# Altium schematic sheet RF_AMP.SchDoc
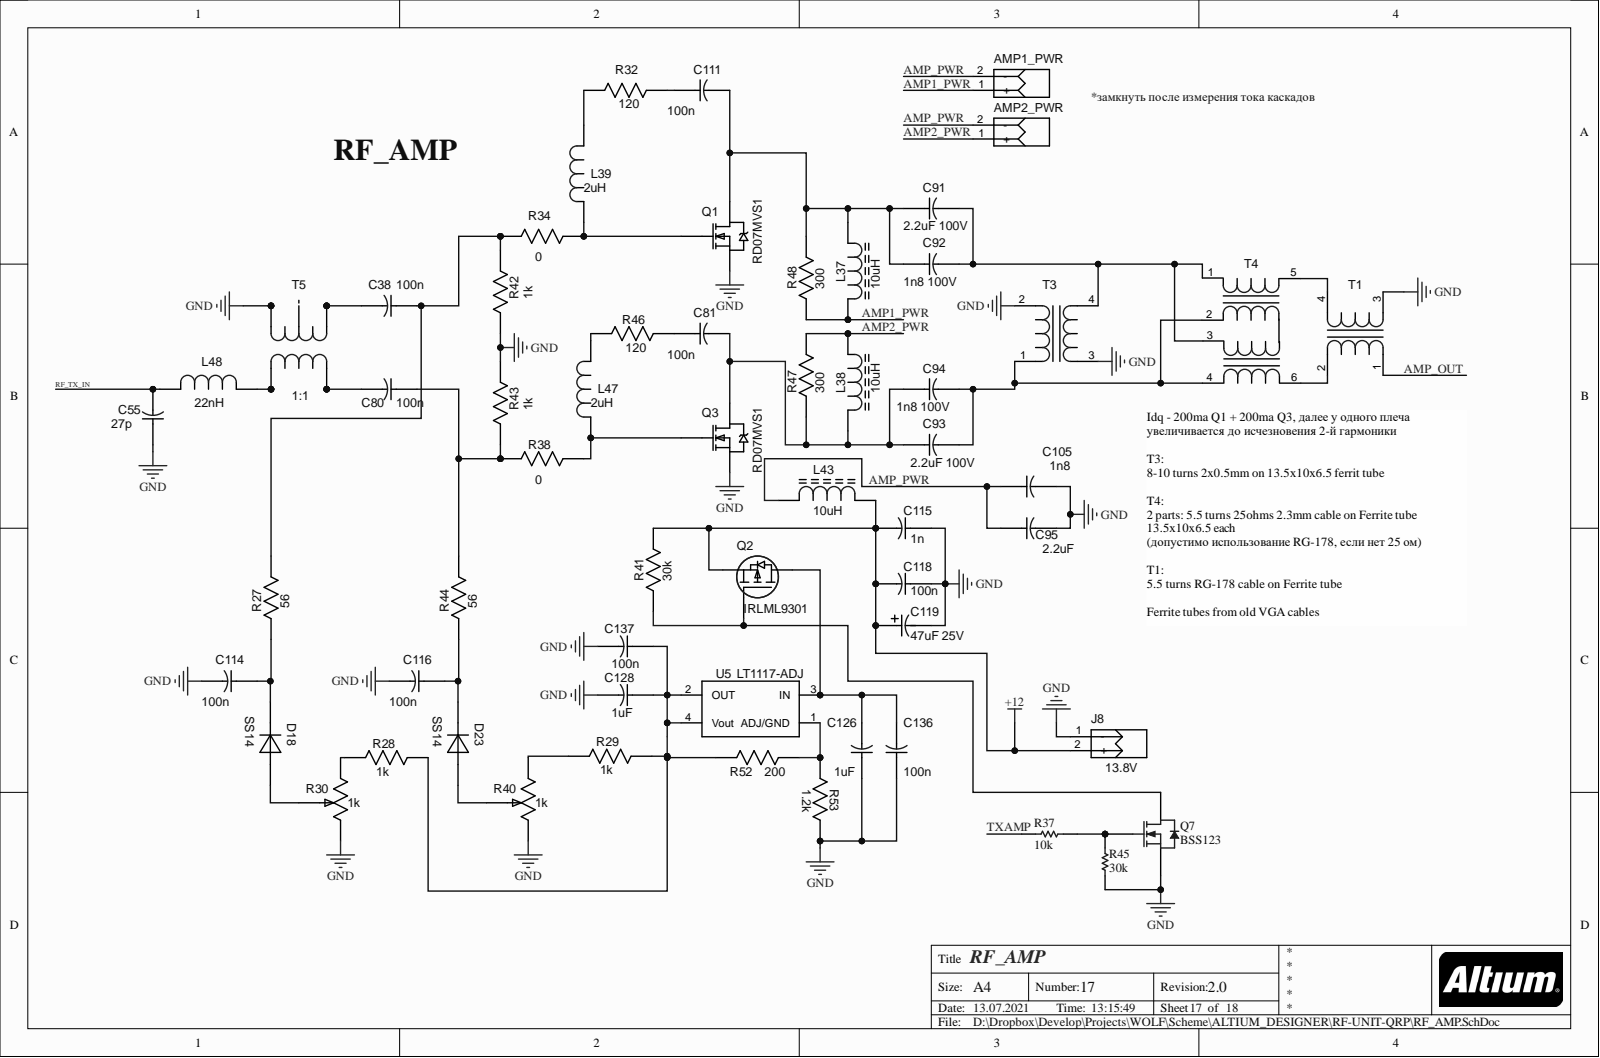
[diagram p0: RF_AMP.SchDoc]

## Parts
AMP1_PWR  51022-0200  [51022-0200]  (value not drawn)
AMP2_PWR  51022-0200  [51022-0200]  (value not drawn)
C38  100n  [CAP_0805]
C55  27p  [CAP_0805]
C80  100n  [CAP_0805]
C81  100n  [CAP_1206]
C91  2.2uF 100V  [CAP_1206]
C92  1n8 100V  [CAP_1206]
C93  2.2uF 100V  [CAP_1206]
C94  1n8 100V  [CAP_1206]
C95  2.2uF  [CAP_1206]
C105  1n8  [CAP_1206]
C111  100n  [CAP_1206]
C114  100n  [CAP_0805]
C115  1n  [CAP_0805]
C116  100n  [CAP_0805]
C118  100n  [CAP_0805]
C119  47uF 25V  [CAPAE_6.6x6.6h5.4]
C126  1uF  [CAP_0805]
C128  1uF  [CAP_0805]
C136  100n  [CAP_0805]
C137  100n  [CAP_0805]
D18  SS14  [SS14]
D23  SS14  [SS14]
J8  value 13.8V  [1986717-2]  (hidden comment: JX2)
L37  10uH  [IND_FERRITE_CORE]
L38  10uH  [IND_FERRITE_CORE]
L39  2uH  [IND_0805]
L43  10uH  [IND_FERRITE_CORE]
L47  2uH  [IND_0805]
L48  22nH  [IND_0805]
Q1  RD07MVS1  [RD07MVS1]
Q2  IRLML9301  [SOT23]
Q3  RD07MVS1  [RD07MVS1]
Q7  BSS123  [FAIR-SOT23-3_V]
R27  56  [RES_0805]
R28  1k  [RES_0805]
R29  1k  [RES_0805]
R30  value 1k  [306J]  (hidden comment: ADJ-1k)
R32  120  [RES_0805]
R34  0  [RES_1206]
R37  10k  [RESC2013X60X40NL20T25]
R38  0  [RES_1206]
R40  value 1k  [306J]  (hidden comment: ADJ-1k)
R41  30k  [RES_0805]
R42  1k  [RES_0805]
R43  1k  [RES_0805]
R44  56  [RES_0805]
R45  30k  [RESC2013X60X40NL20T25]
R46  120  [RES_0805]
R47  300  [RES_1206]
R48  300  [RES_1206]
R52  200  [RES_0805]
R53  1.2k  [RES_0805]
T1  8T M2000HM 10*6*5  [Rutrof 2]  (value not drawn)
T3  8T M2000HM 10*6*5  [Rutrof]  (value not drawn)
T4  TRANSF_OUT  [TRANSF_OUT]  (value not drawn)
T5  1:1  [TRANSF_ACORE2]
U5  value LT1117-ADJ  [LT1117IST-ADJ]  (hidden comment: LT1117IST-ADJ)

## Nets
+12: C115.2 C118.2 C119.1 J8.2 L43.2 Q2.2 R41.2
GND: C55.1 C95.2 C105.2 C114.2 C115.1 C116.2 C118.1 C119.2 C126.1 C128.2 C136.1 C137.2 J8.1 Q1.2 Q3.2 Q7.2 R30.1 R40.1 R42.1 R43.2 R45.1 R53.2 T1.3 T3.2 T3.3 T5.3 T5.9
AMP1_PWR: AMP1_PWR.1 L37.2 R48.1
AMP2_PWR: AMP2_PWR.1 L38.1 R47.2
AMP_OUT: T1.1
AMP_PWR: AMP1_PWR.2 AMP2_PWR.2 C95.1 C105.1 L43.1
RF_TX_IN: C55.2 L48.1
TXAMP: R37.2
NetC38_1: C38.1 R27.2 R34.1 R42.2
NetC38_2: C38.2 T5.1 T5.7
NetC80_1: C80.1 R38.1 R43.1 R44.2
NetC80_2: C80.2 T5.6 T5.10
NetC81_1: C81.1 R46.2
NetC81_2: C81.2 C93.1 C94.1 L38.2 Q3.1 R47.1
NetC91_1: C91.1 C92.1 C111.2 L37.1 Q1.1 R48.2
NetC91_2: C91.2 C92.2 T3.4 T4.1 T4.3
NetC93_2: C93.2 C94.2 T3.1 T4.2 T4.4
NetC111_1: C111.1 R32.2
NetC114_1: C114.1 D18.1 R27.1
NetC116_1: C116.1 D23.1 R44.1
NetC126_2: C126.2 C136.2 Q2.3 U5.3(IN)
NetC128_1: C128.1 C137.1 R28.2 R29.2 R52.1 U5.2(OUT) U5.4(Vout)
NetD18_2: D18.2 R30.2
NetD23_2: D23.2 R40.2
NetL39_1: L39.1 Q1.3 R34.2
NetL39_2: L39.2 R32.1
NetL47_1: L47.1 Q3.3 R38.2
NetL47_2: L47.2 R46.1
NetL48_2: L48.2 T5.4 T5.11
NetQ2_1: Q2.1 Q7.3 R41.1
NetQ7_1: Q7.1 R37.1 R45.2
NetR28_1: R28.1 R30.3
NetR29_1: R29.1 R40.3
NetR52_2: R52.2 R53.1 U5.1(ADJ/GND)
NetT1_2: T1.2 T4.6
NetT1_4: T1.4 T4.5

Not connected: T5 2 8

## Library data of the parts
C38 C55 C80 C114-C116 C118 C126 C128 C136 C137 = CAP_0805: Component Kind: Standard; Description: CAP_0805
  C114 C116 C118 C136 C137: Value: 100n
  C115: Value: 1n
  C126 C128: Value: 1uF
C81 C91-C95 C105 C111 = CAP_1206: Component Kind: Standard; Description: CAP_1206
  C91 C93: Value: 2.2uF 100V
  C92 C94: Value: 1n8 100V
C119 = CAPAE_6.6x6.6h5.4: Component Kind: Standard; Description: CAPAE_6.6x6.6h5.4; Value: 47uF 25V
J8 = 1986717-2: Component Kind: Standard; Description: JX2
L37 L38 L43 = IND_FERRITE_CORE: Inductor; Component Kind: Standard; Value: 10uH
L39 L47 L48 = IND_0805: Component Kind: Standard; Description: IND_0805
Q2 = MOSFET_ENH_PD: MOSFET; Component Kind: Standard; Value: IRLML9301
Q7 = CMP-2000-04934-1: N-Channel Logic Level Enhancement Mode Field Effect Transistor, 1.7 V, -55 to 150 degC, 3-Pin SOT-23, RoHS, Tape and Reel; Manufacturer: Fairchild; Channel Type: N; Maximum Continuous Drain Current: 0.17A; Maximum Drain Source Voltage: 100V; Maximum Gate Source Voltage: ±20V; Package Version: Rev. 12, 03/2016; Rad Hard: No; RDS-on: 6000@10VmOhm; Typical Fall Time: 2.4ns; Typical Rise Time: 9ns; Typical Turn-Off Delay Time: 17ns; Typical Turn-On Delay Time: 1.7ns; Category: MOSFET; Channel Mode: Enhancement; Component Kind: Standard; Datasheet URL: https://www.fairchildsemi.com/datasheets/BS/BSS123.pdf; Datasheet Version: Jun-03; Library Name: Altium Content Vault; Manufacturer URL: https://www.fairchildsemi.com/; Mounting: SurfaceMount; Operating Temperature: -55to150degC; Package: 3SOT-23; Package Description: 3-Pin Small Outline Transistor, Body 2.92 x 1.325 mm, Pitch 0.95 mm; Package Reference: SOT23-3; Package URL: http://www.fairchildsemi.com/dwg/MA/MA03D.pdf; Packaging: Tape&Reel
R27-R29 R32 R41-R44 R46 R52 R53 = RES_0805: Component Kind: Standard; Description: RES_0805
  R27 R44: Value: 56
  R28 R29 R42 R43: Value: 1k
  R41: Value: 30k
  R52: Value: 200
  R53: Value: 1.2k
R30 R40 = 306J: Component Kind: Standard; Description: 306J
R34 R38 R47 R48 = RES_1206: Component Kind: Standard; Description: RES_1206
  R47 R48: Value: 300
R37 R45 = CMP-2001-00626-1: RES_0805; Manufacturer: Panasonic; Part Number: ERA-6AEB303V; Component Kind: Standard; Library Name: Altium Content Vault
T1 T3 = TRANSF_ICORE: Transformer; Component Kind: Standard
T4 = TRANSF_OUT_2: Component Kind: Standard; Description: TRANSF_OUT; Library Name: RF-UNIT.SchLib
T5 = TRANSF_ACORE2: Component Kind: Standard; Description: 1:1; Library Name: RF-UNIT_1.SCHLIB; Value: 1:1
AMP1_PWR AMP2_PWR = 51022-0200: Component Kind: Standard; Description: 51022-0200
D18 D23 = SS14: Component Kind: Standard; Description: SS14; Value: SS14
Q1 Q3 = RD07MVS1: Component Kind: Standard; Description: RD07MVS1; Value: RD07MVS1
U5 = LT1117IST-ADJ: Component Kind: Standard; Description: LT1117IST-ADJ
